# Altium schematic sheet atmega.SchDoc(atmega)
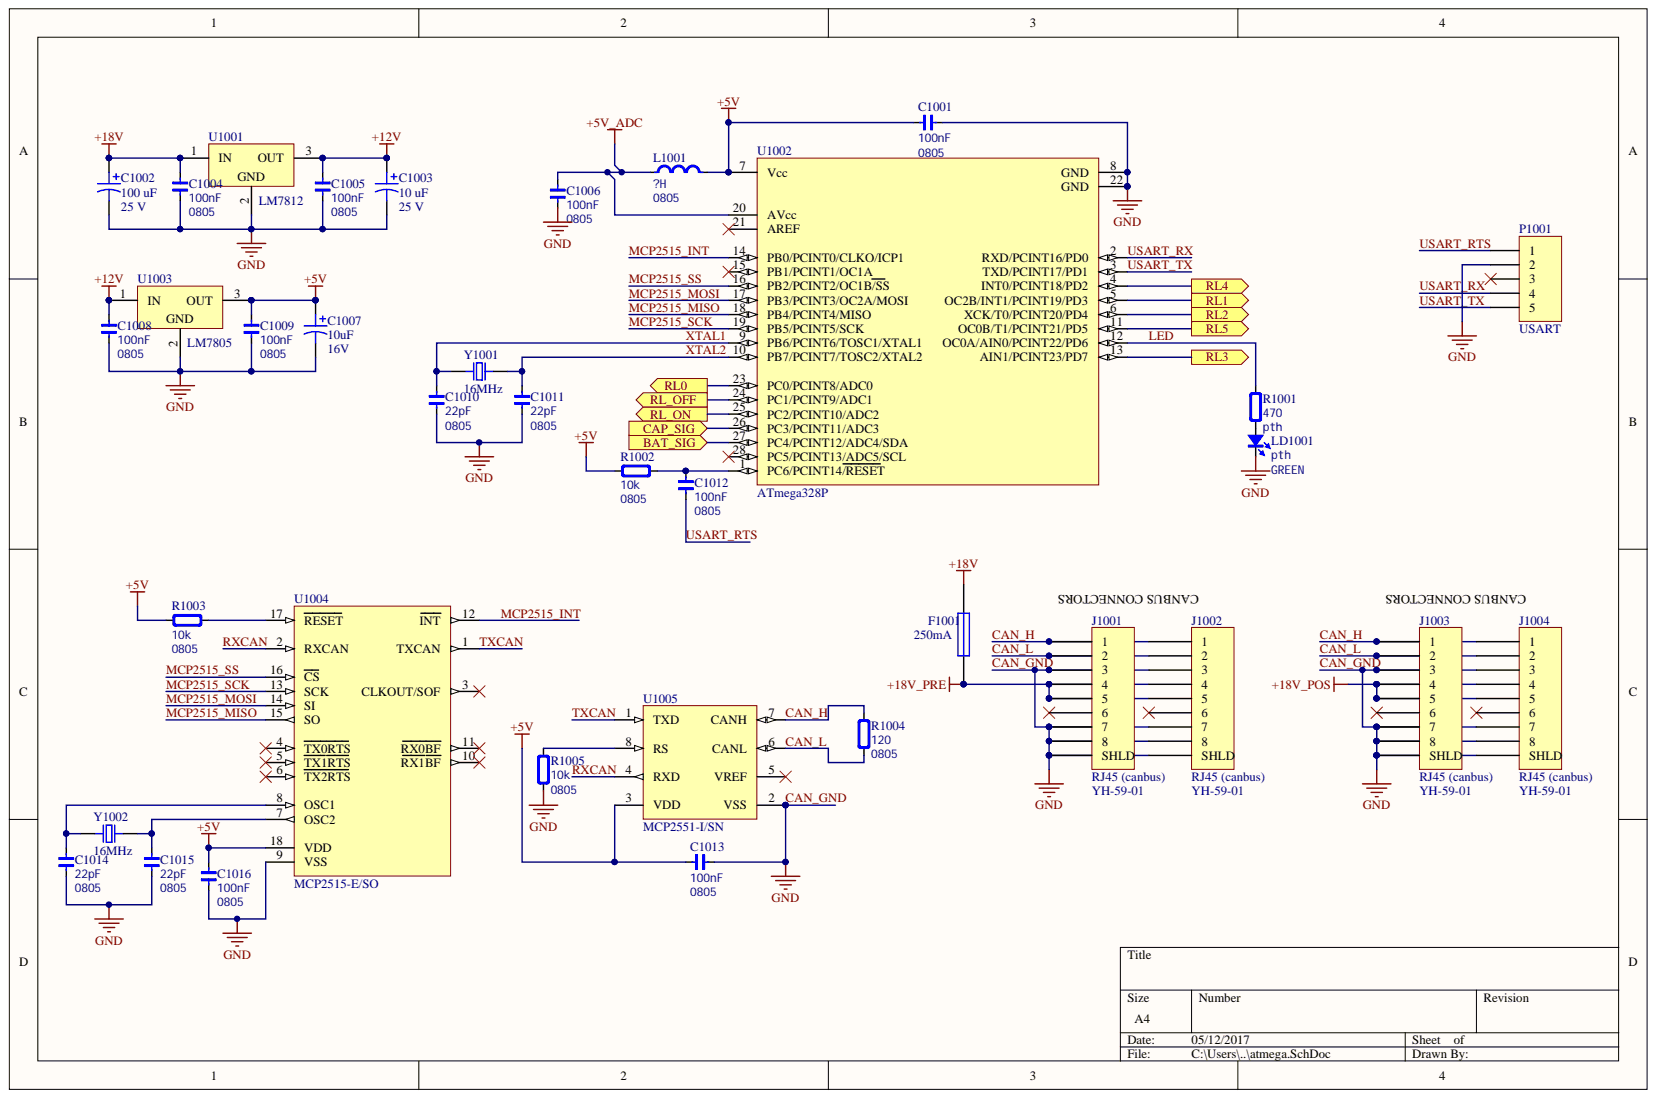
[diagram p0: atmega.SchDoc]

## Parts
C1001  100nF  [0805C]
C1002  value 100 uF  Voltage: 25 V  [CP3.5mm-8.0mm]  (hidden comment: CapacitorPol)
C1003  value 10 uF  Voltage: 25 V  [CP3.5mm-8.0mm]  (hidden comment: CapacitorPol)
C1004  100nF  [0805C]
C1005  100nF  Type: 0805  [0805C]
C1006  100nF  Type: 0805  [0805C]
C1007  value 10uF  Voltage: 16V  [CP3.5mm-8.0mm]  (hidden comment: CapacitorPol)
C1008  100nF  [0805C]
C1009  100nF  Type: 0805  [0805C]
C1010  22pF  Type: 0805  [0805C]
C1011  22pF  Type: 0805  [0805C]
C1012  100nF  Type: 0805  [0805C]
C1013  100nF  Type: 0805  [0805C]
C1014  22pF  Type: 0805  [0805C]
C1015  22pF  Type: 0805  [0805C]
C1016  100nF  Type: 0805  [0805C]
F1001  250mA  [Porta Fusivel]
J1001  RJ45 (canbus)  value YH-59-01  Type: RJ45  [ASSMANN A-2004-2-4-LP/FS]
J1002  RJ45 (canbus)  value YH-59-01  Type: RJ45  [ASSMANN A-2004-2-4-LP/FS]
J1003  RJ45 (canbus)  value YH-59-01  Type: RJ45  [ASSMANN A-2004-2-4-LP/FS]
J1004  RJ45 (canbus)  value YH-59-01  Type: RJ45  [ASSMANN A-2004-2-4-LP/FS]
L1001  ?H  Type: 0805  [0805L]
LD1001  GREEN  Type: pth  [LED3MM]
P1001  USART  [HDR1X5]
R1001  470  Type: pth  [AXIAL-0.3]
R1002  10k  Type: 0805  [0805R]
R1003  10k  Type: 0805  [0805R]
R1004  120  Type: 0805  [0805R]
R1005  10k  Type: 0805  [0805R]
U1001  LM7812  [TO220_deitado]
U1002  ATmega328P  [DIP28-300(100)]
U1003  LM7805  [TO220_deitado]
U1004  MCP2515-E/SO  [WSO18_L]
U1005  MCP2551-I/SN  [SOIC08-150(50)]
Y1001  16MHz  [HC49-US-THT]
Y1002  16MHz  [HC49-US-THT]

## Ports
BAT_SIG, CAP_SIG, RL0, RL1, RL2, RL3, RL4, RL5, RL_OFF, RL_ON

## Nets
+5V: C1001.1 C1007.1 C1009.2 C1013.1 C1016.1 L1001.2 R1002.1 R1003.1 U1002.7(Vcc) U1003.3 U1004.18(VDD) U1005.3(VDD)
+5V_ADC: C1006.2 L1001.1 U1002.20(AVcc)
+12V: C1003.1 C1005.2 C1008.2 U1001.3 U1003.1
+18V: C1002.1 C1004.2 F1001.1 U1001.1
+18V_POS: J1003.4 J1003.5 J1004.4 J1004.5
+18V_PRE: F1001.2 J1001.4 J1001.5 J1002.4 J1002.5
BAT_SIG: U1002.27(PC4/PCINT12/ADC4/SDA) ; port BAT_SIG
CAN_GND: C1001.2 C1002.2 C1003.2 C1004.1 C1005.1 C1006.1 C1007.2 C1008.1 C1009.1 C1010.1 C1011.1 C1013.2 C1014.1 C1015.1 C1016.2 J1001.3 J1001.7 J1001.8 J1001.S(SHLD) J1002.3 J1002.7 J1002.8 J1002.S(SHLD) J1003.3 J1003.7 J1003.8 J1003.S(SHLD) J1004.3 J1004.7 J1004.8 J1004.S(SHLD) LD1001.2 P1001.2 R1005.2 U1001.2 U1002.8(GND) U1002.22(GND) U1003.2 U1004.9(VSS) U1005.2(VSS)
CAN_H: J1001.1 J1002.1 J1003.1 J1004.1 R1004.2 U1005.7(CANH)
CAN_L: J1001.2 J1002.2 J1003.2 J1004.2 R1004.1 U1005.6(CANL)
CAP_SIG: U1002.26(PC3/PCINT11/ADC3) ; port CAP_SIG
LED: R1001.2 U1002.12(OC0A/AIN0/PCINT22/PD6)
MCP2515_INT: U1002.14(PB0/PCINT0/CLKO/ICP1) U1004.12(INT)
MCP2515_MISO: U1002.18(PB4/PCINT4/MISO) U1004.15(SO)
MCP2515_MOSI: U1002.17(PB3/PCINT3/OC2A/MOSI) U1004.14(SI)
MCP2515_SCK: U1002.19(PB5/PCINT5/SCK) U1004.13(SCK)
MCP2515_SS: U1002.16(PB2/PCINT2/OC1B/SS) U1004.16(CS)
RL0: U1002.23(PC0/PCINT8/ADC0) ; port RL0
RL1: U1002.5(OC2B/INT1/PCINT19/PD3) ; port RL1
RL2: U1002.6(XCK/T0/PCINT20/PD4) ; port RL2
RL3: U1002.13(AIN1/PCINT23/PD7) ; port RL3
RL4: U1002.4(INT0/PCINT18/PD2) ; port RL4
RL5: U1002.11(OC0B/T1/PCINT21/PD5) ; port RL5
RL_OFF: U1002.24(PC1/PCINT9/ADC1) ; port RL_OFF
RL_ON: U1002.25(PC2/PCINT10/ADC2) ; port RL_ON
RXCAN: U1004.2(RXCAN) U1005.4(RXD)
TXCAN: U1004.1(TXCAN) U1005.1(TXD)
USART_RTS: C1012.2 P1001.1
USART_RX: P1001.4 U1002.2(RXD/PCINT16/PD0)
USART_TX: P1001.5 U1002.3(TXD/PCINT17/PD1)
XTAL1: C1010.2 U1002.9(PB6/PCINT6/TOSC1/XTAL1) Y1001.1
XTAL2: C1011.2 U1002.10(PB7/PCINT7/TOSC2/XTAL2) Y1001.2
NetC1012_1: C1012.1 R1002.2 U1002.1(PC6/PCINT14/RESET)
NetC1014_2: C1014.2 U1004.8(OSC1) Y1002.1
NetC1015_2: C1015.2 U1004.7(OSC2) Y1002.2
NetLD1001_1: LD1001.1 R1001.1
NetR1003_2: R1003.2 U1004.17(RESET)
NetR1005_1: R1005.1 U1005.8(RS)

Not connected: J1001 6; J1002 6; J1003 6; J1004 6; P1001 3; U1002 15(PB1/PCINT1/OC1A) 21(AREF) 28(PC5/PCINT13/ADC5/SCL); U1004 3(CLKOUT/SOF) 4(TX0RTS) 5(TX1RTS) 6(TX2RTS) 10(RX1BF) 11(RX0BF); U1005 5(VREF)

## Library data of the parts
C1001 C1004 C1008 = Cap_0805: Capacitor; Type: 0805; Component Kind: Standard; Library Name: SCH_Passive.SchLib; Value: 100nF
C1002 C1003 C1007 = CapacitorPol: Solid Aluminum Capacitor with Organic Semiconductor Electrolyte: 100uF, 10V, Bulk Pack; Type: pth; Component Kind: Standard; Simulation: CAP; Library Name: R2R - Nova.intlib
C1005 C1006 C1009-C1016 = Cap_0805: Capacitor; Component Kind: Standard; Library Name: SCH_Passive.SchLib
  C1005 C1006 C1009 C1012 C1013 C1016: Value: 100nF
  C1010 C1011 C1014 C1015: Value: 22pF
F1001 = Fuse 1: Fuse; Type: 5x20; Component Kind: Standard; Library Name: Miscellaneous Devices.IntLib; Simulation: FUSE; Value: 250mA
J1001-J1004 = Modular Jack with Shield: YH-59-01; Component Kind: Standard; Library Name: Connectors.SchLib
L1001 = Ind_0805: Inductor; Component Kind: Standard; Library Name: SCH_Passive.SchLib; Value: ?H
LD1001 = LED_0805: LED; Component Kind: Standard; Library Name: SCH_Active.SchLib; Value: GREEN
P1001 = Header 5: Header, 5-Pin; Component Kind: Standard; Library Name: R2R - Nova.intlib
R1001-R1005 = Res_0805: Resistor; Component Kind: Standard; Library Name: SCH_Passive.SchLib
  R1001: Value: 470
  R1002 R1003 R1005: Value: 10k
  R1004: Value: 120
U1001 U1003 = µA7805CKC: Positive-Voltage Regulator; Component Kind: Standard; Library Name: TI Power Mgt Voltage Regulator.IntLib
U1002 = ATmega328P: 8-bit AVR Microcontroller, 32KB Flash, 1KB EEPROM, 2KB SRAM, 28-pin PDIP, Industrial Grade (-40°C to 85°C); Component Kind: Standard; Library Name: R2R - Nova.intlib
U1004 = CMP-0186-00035-2: Stand-Alone CAN Controller With SPI Interface, 18-Pin SOIC, Extended Temperature; Component Kind: Standard; Library Name: Altium Content Vault
U1005 = CMP-1721-00003-1: High-Speed CAN Transceiver, 1 Mb/s, 4.5 to 5.5 V, 8-Pin SOIC (SN), Industrial Temperature, RoHS, Tube; Component Kind: Standard; Library Name: Altium Content Vault
Y1001 Y1002 = Crystal: Component Kind: Standard; Description: Crystal; Type: pth; Library Name: R2R - Nova.intlib
